# Altium schematic sheet AN-DGX - CPLD.SchDoc("AN-DGX - CPLD")
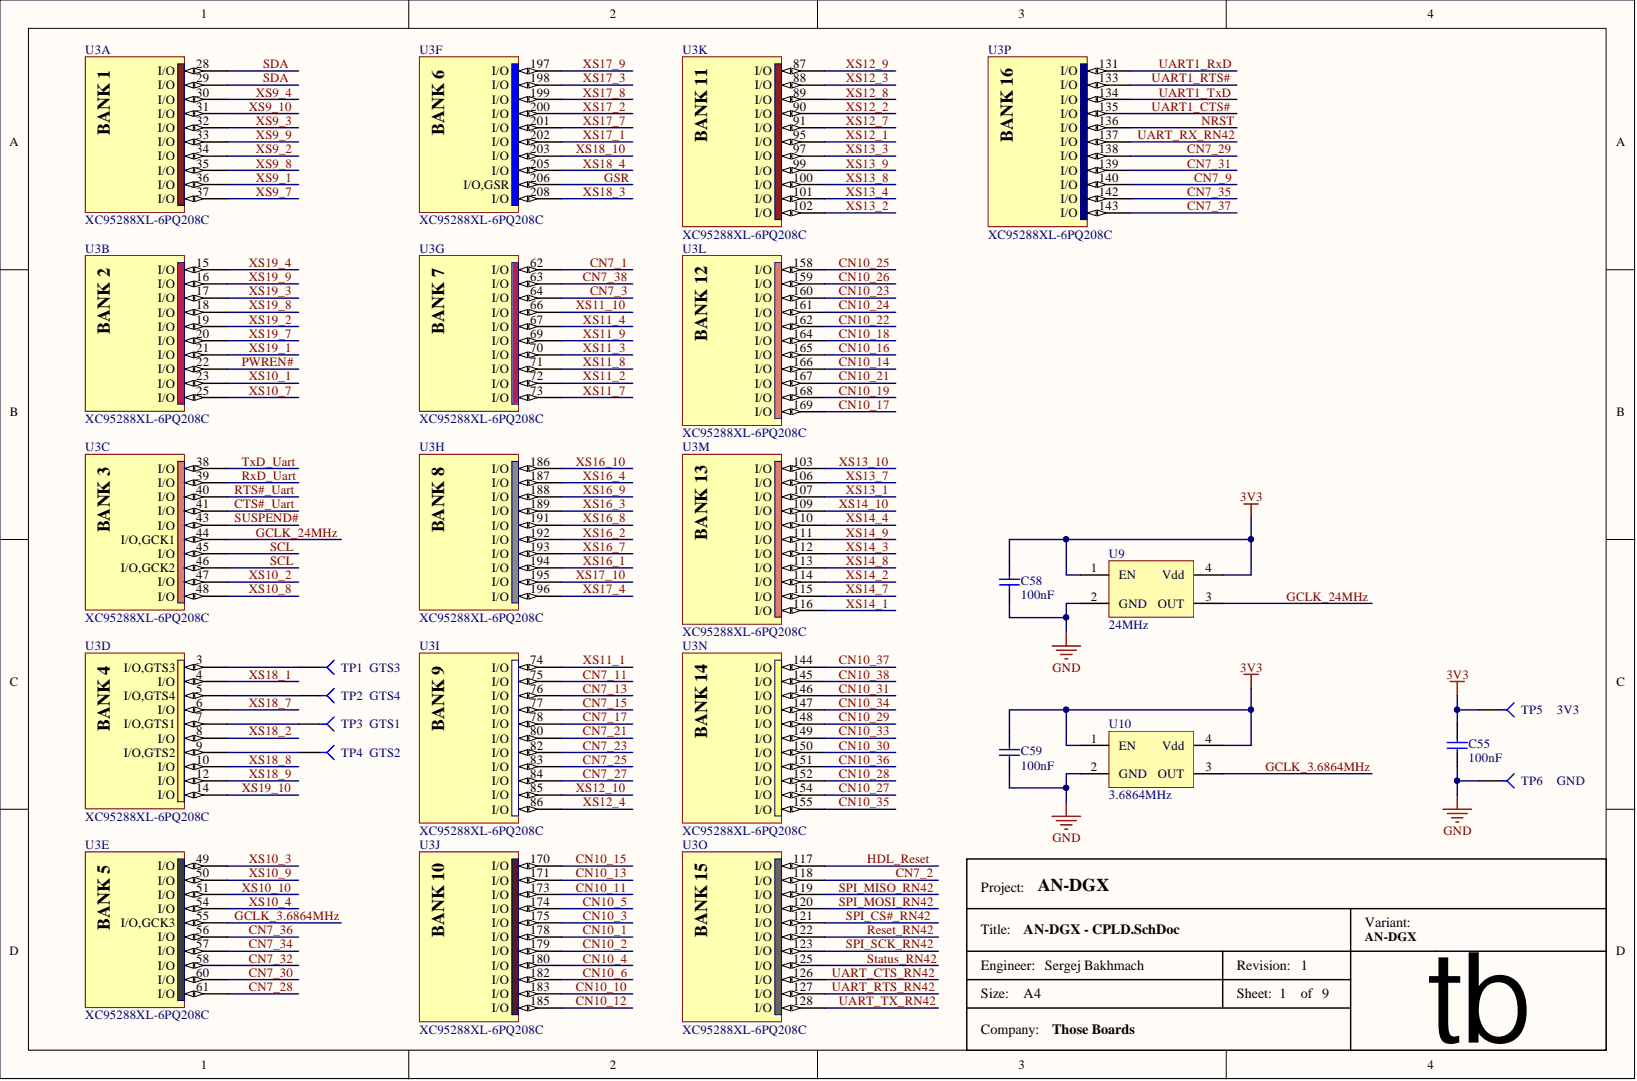
[diagram p0: AN-DGX - CPLD.SchDoc]

## Parts
C55  value 100nF  [C0603]  (hidden comment: CAP)
C58  value 100nF  [C0603]  (hidden comment: CAP)
C59  value 100nF  [C0603]  (hidden comment: CAP)
TP1  GTS3  [TP_1mm_hole]  (no BOM)
TP2  GTS4  [TP_1mm_hole]  (no BOM)
TP3  GTS1  [TP_1mm_hole]  (no BOM)
TP4  GTS2  [TP_1mm_hole]  (no BOM)
TP5  3V3  [TP_1mm_hole]  (no BOM)
TP6  GND  [TP_1mm_hole]  (no BOM)
U3  XC95288XL-6PQ208C  [PQ208 - XC95288XL]  (parts A,B,C,D,E,F,G,H,I,J,K,L,M,N,O,P)
U9  value 24MHz  [OSC_5x7]  (hidden comment: OSC_Crystal Oscillator)
U10  value 3.6864MHz  [OSC_5x7]  (hidden comment: OSC_Crystal Oscillator)

## Nets
3V3: C55.2 C58.1 C59.1 TP5.1 U9.1(EN) U9.4(Vdd) U10.1(EN) U10.4(Vdd)
GND: C55.1 C58.2 C59.2 TP6.1 U9.2(GND) U10.2(GND)
CN7_1: U3.62(I/O)
CN7_2: U3.118(I/O)
CN7_3: U3.64(I/O)
CN7_9: U3.140(I/O)
CN7_11: U3.75(I/O)
CN7_13: U3.76(I/O)
CN7_15: U3.77(I/O)
CN7_17: U3.78(I/O)
CN7_21: U3.80(I/O)
CN7_23: U3.82(I/O)
CN7_25: U3.83(I/O)
CN7_27: U3.84(I/O)
CN7_28: U3.61(I/O)
CN7_29: U3.138(I/O)
CN7_30: U3.60(I/O)
CN7_31: U3.139(I/O)
CN7_32: U3.58(I/O)
CN7_34: U3.57(I/O)
CN7_35: U3.142(I/O)
CN7_36: U3.56(I/O)
CN7_37: U3.143(I/O)
CN7_38: U3.63(I/O)
CN10_1: U3.178(I/O)
CN10_2: U3.179(I/O)
CN10_3: U3.175(I/O)
CN10_4: U3.180(I/O)
CN10_5: U3.174(I/O)
CN10_6: U3.182(I/O)
CN10_10: U3.183(I/O)
CN10_11: U3.173(I/O)
CN10_12: U3.185(I/O)
CN10_13: U3.171(I/O)
CN10_14: U3.166(I/O)
CN10_15: U3.170(I/O)
CN10_16: U3.165(I/O)
CN10_17: U3.169(I/O)
CN10_18: U3.164(I/O)
CN10_19: U3.168(I/O)
CN10_21: U3.167(I/O)
CN10_22: U3.162(I/O)
CN10_23: U3.160(I/O)
CN10_24: U3.161(I/O)
CN10_25: U3.158(I/O)
CN10_26: U3.159(I/O)
CN10_27: U3.154(I/O)
CN10_28: U3.152(I/O)
CN10_29: U3.148(I/O)
CN10_30: U3.150(I/O)
CN10_31: U3.146(I/O)
CN10_33: U3.149(I/O)
CN10_34: U3.147(I/O)
CN10_35: U3.155(I/O)
CN10_36: U3.151(I/O)
CN10_37: U3.144(I/O)
CN10_38: U3.145(I/O)
CTS#_Uart: U3.41(I/O)
GCLK_3.6864MHz: U3.55(I/O,GCK3) U10.3(OUT)
GCLK_24MHz: U3.44(I/O,GCK1) U9.3(OUT)
GSR: U3.206(I/O,GSR)
HDL_Reset: U3.117(I/O)
NRST: U3.136(I/O)
PWREN#: U3.22(I/O)
Reset_RN42: U3.122(I/O)
RTS#_Uart: U3.40(I/O)
RxD_Uart: U3.39(I/O)
SCL: U3.45(I/O) U3.46(I/O,GCK2)
SDA: U3.28(I/O) U3.29(I/O)
SPI_CS#_RN42: U3.121(I/O)
SPI_MISO_RN42: U3.119(I/O)
SPI_MOSI_RN42: U3.120(I/O)
SPI_SCK_RN42: U3.123(I/O)
Status_RN42: U3.125(I/O)
SUSPEND#: U3.43(I/O)
TxD_Uart: U3.38(I/O)
UART1_CTS#: U3.135(I/O)
UART1_RTS#: U3.133(I/O)
UART1_RxD: U3.131(I/O)
UART1_TxD: U3.134(I/O)
UART_CTS_RN42: U3.126(I/O)
UART_RTS_RN42: U3.127(I/O)
UART_RX_RN42: U3.137(I/O)
UART_TX_RN42: U3.128(I/O)
XS9_1: U3.36(I/O)
XS9_2: U3.34(I/O)
XS9_3: U3.32(I/O)
XS9_4: U3.30(I/O)
XS9_7: U3.37(I/O)
XS9_8: U3.35(I/O)
XS9_9: U3.33(I/O)
XS9_10: U3.31(I/O)
XS10_1: U3.23(I/O)
XS10_2: U3.47(I/O)
XS10_3: U3.49(I/O)
XS10_4: U3.54(I/O)
XS10_7: U3.25(I/O)
XS10_8: U3.48(I/O)
XS10_9: U3.50(I/O)
XS10_10: U3.51(I/O)
XS11_1: U3.74(I/O)
XS11_2: U3.72(I/O)
XS11_3: U3.70(I/O)
XS11_4: U3.67(I/O)
XS11_7: U3.73(I/O)
XS11_8: U3.71(I/O)
XS11_9: U3.69(I/O)
XS11_10: U3.66(I/O)
XS12_1: U3.95(I/O)
XS12_2: U3.90(I/O)
XS12_3: U3.88(I/O)
XS12_4: U3.86(I/O)
XS12_7: U3.91(I/O)
XS12_8: U3.89(I/O)
XS12_9: U3.87(I/O)
XS12_10: U3.85(I/O)
XS13_1: U3.107(I/O)
XS13_2: U3.102(I/O)
XS13_3: U3.97(I/O)
XS13_4: U3.101(I/O)
XS13_7: U3.106(I/O)
XS13_8: U3.100(I/O)
XS13_9: U3.99(I/O)
XS13_10: U3.103(I/O)
XS14_1: U3.116(I/O)
XS14_2: U3.114(I/O)
XS14_3: U3.112(I/O)
XS14_4: U3.110(I/O)
XS14_7: U3.115(I/O)
XS14_8: U3.113(I/O)
XS14_9: U3.111(I/O)
XS14_10: U3.109(I/O)
XS16_1: U3.194(I/O)
XS16_2: U3.192(I/O)
XS16_3: U3.189(I/O)
XS16_4: U3.187(I/O)
XS16_7: U3.193(I/O)
XS16_8: U3.191(I/O)
XS16_9: U3.188(I/O)
XS16_10: U3.186(I/O)
XS17_1: U3.202(I/O)
XS17_2: U3.200(I/O)
XS17_3: U3.198(I/O)
XS17_4: U3.196(I/O)
XS17_7: U3.201(I/O)
XS17_8: U3.199(I/O)
XS17_9: U3.197(I/O)
XS17_10: U3.195(I/O)
XS18_1: U3.4(I/O)
XS18_2: U3.8(I/O)
XS18_3: U3.208(I/O)
XS18_4: U3.205(I/O)
XS18_7: U3.6(I/O)
XS18_8: U3.10(I/O)
XS18_9: U3.12(I/O)
XS18_10: U3.203(I/O)
XS19_1: U3.21(I/O)
XS19_2: U3.19(I/O)
XS19_3: U3.17(I/O)
XS19_4: U3.15(I/O)
XS19_7: U3.20(I/O)
XS19_8: U3.18(I/O)
XS19_9: U3.16(I/O)
XS19_10: U3.14(I/O)
NetTP1_1: TP1.1 U3.3(I/O,GTS3)
NetTP2_1: TP2.1 U3.5(I/O,GTS4)
NetTP3_1: TP3.1 U3.7(I/O,GTS1)
NetTP4_1: TP4.1 U3.9(I/O,GTS2)

## Library data of the parts
C55 C58 C59 = CAP: Manufacturer Part: VJ0603Y104JXJPW1BC; Manufacturer: Vishay / Vitramon; Type of Component: Ceramic Capacitor; Supplier 3 Part: 001832; Supplier 3 Link: http://voron.ua/catalog/001832; Supplier 3: http://voron.ua; Supplier 2 Part: 182925; Supplier 2 Link: http://imrad.com.ua/ru/cc0603krx7r8bb104; Supplier 2: http://imrad.com.ua/; Supplier 1 Link: aliexpress.com/item/100pcs-lot-100nF-X7R-Error-10-50V-0603-smd-capacitor/32318089455.html?spm=2114.03010208.3.2.PDNk2A&ws_ab_test=searchweb201556_8,searchweb201602_1_10065_10068_112_10069_110_111_418_10017_109_108_10060_10061_10062_10057_10039_10056_10055_10054_10059_10058_10073_10070_10052_10053_10050_10051,searchweb201603_1&btsid=c39062ca-3db8-4562-8db0-c782461646c7; Supplier 1: aliexpress.com; Package / Case: 0603; Manufacturer Link: http://www.vishay.com/product?docid=28548; Component Kind: Standard; Library Name: RPI-VITA.IntLib
TP1-TP6 = TP: Test Point; Library Name: RPI-VITA.IntLib
U3 = XC95288XL-6PQ208C: FastFLASH XC900XL, 3.3V High-Performance CPLD with 288 Macrocells, 208-Pin PQFP, Commercial Grade; Manufacturer: Xilinx; Value: XC95288XL-6PQ208C; Type of Component: CPLD; Supplier 1 Part: XC95288XL-6PQ208C; Supplier 1: HK-Ada; Published: 17-Jul-2002; PackageVersion: ver1.2, Jun-2004; PackageReference: PQ208; PackageDescription: 208-Pin Plastic Quad Flat Pack (PQ208); Package / Case: PQ208; Manufacturer Part: XC95288XL-6PQ208C; Manufacturer Link: http://www.xilinx.com/products/silicon_solutions/cplds/xc9500_series/xc9500xl/index.htm; LatestRevisionNote: IPC-7351 Footprint Added.; LatestRevisionDate: 13-Apr-2006; DatasheetVersion: ver2.0, Mar-2006; ComponentLink2URL: http://direct.xilinx.com/bvdocs/publications/ds055.pdf; ComponentLink2Description: Datasheet; ComponentLink1URL: http://www.xilinx.com/products/silicon_solutions/cplds/xc9500_series/xc9500xl/index.htm; ComponentLink1Description: Manufacturer Link; Component Kind: Standard; Code_JEDEC: MS-029-FA-1; Publisher: Altium Limited; Library Name: RPI-VITA.IntLib
U9 U10 = OSC_Crystal Oscillator: Manufacturer: KKQ; Type of Component: Clock Oscillator; Supplier 1: aliexpress.com; Package / Case: 5 mm x 7 mm; Component Kind: Standard; Library Name: RPI-VITA.IntLib
  U9: Supplier 1 Part: 24MHz 4 pin; Supplier 1 Link: http://aliexpress.com/item/Free-shipping-24-000MHZ-24-000M-24-000-20Pcs-Lot-5070-7050-5-7-SMD-4Pin/1254133788.html; Manufacturer Part: 24MHz
  U10: Supplier 1 Part: 3.6864MHz 4 pin; Supplier 1 Link: http://aliexpress.com/item/100PCS-Original-NEW-3-6864MHZ-3-6864M-3-6864-5-7-4P-5070-OSC-5-00mm/32275012196.html?detailNewVersion=1; Manufacturer Part: 3.6864MHz
